# Altium schematic sheet PolarityProtection.SchDoc(PolarityProtection)
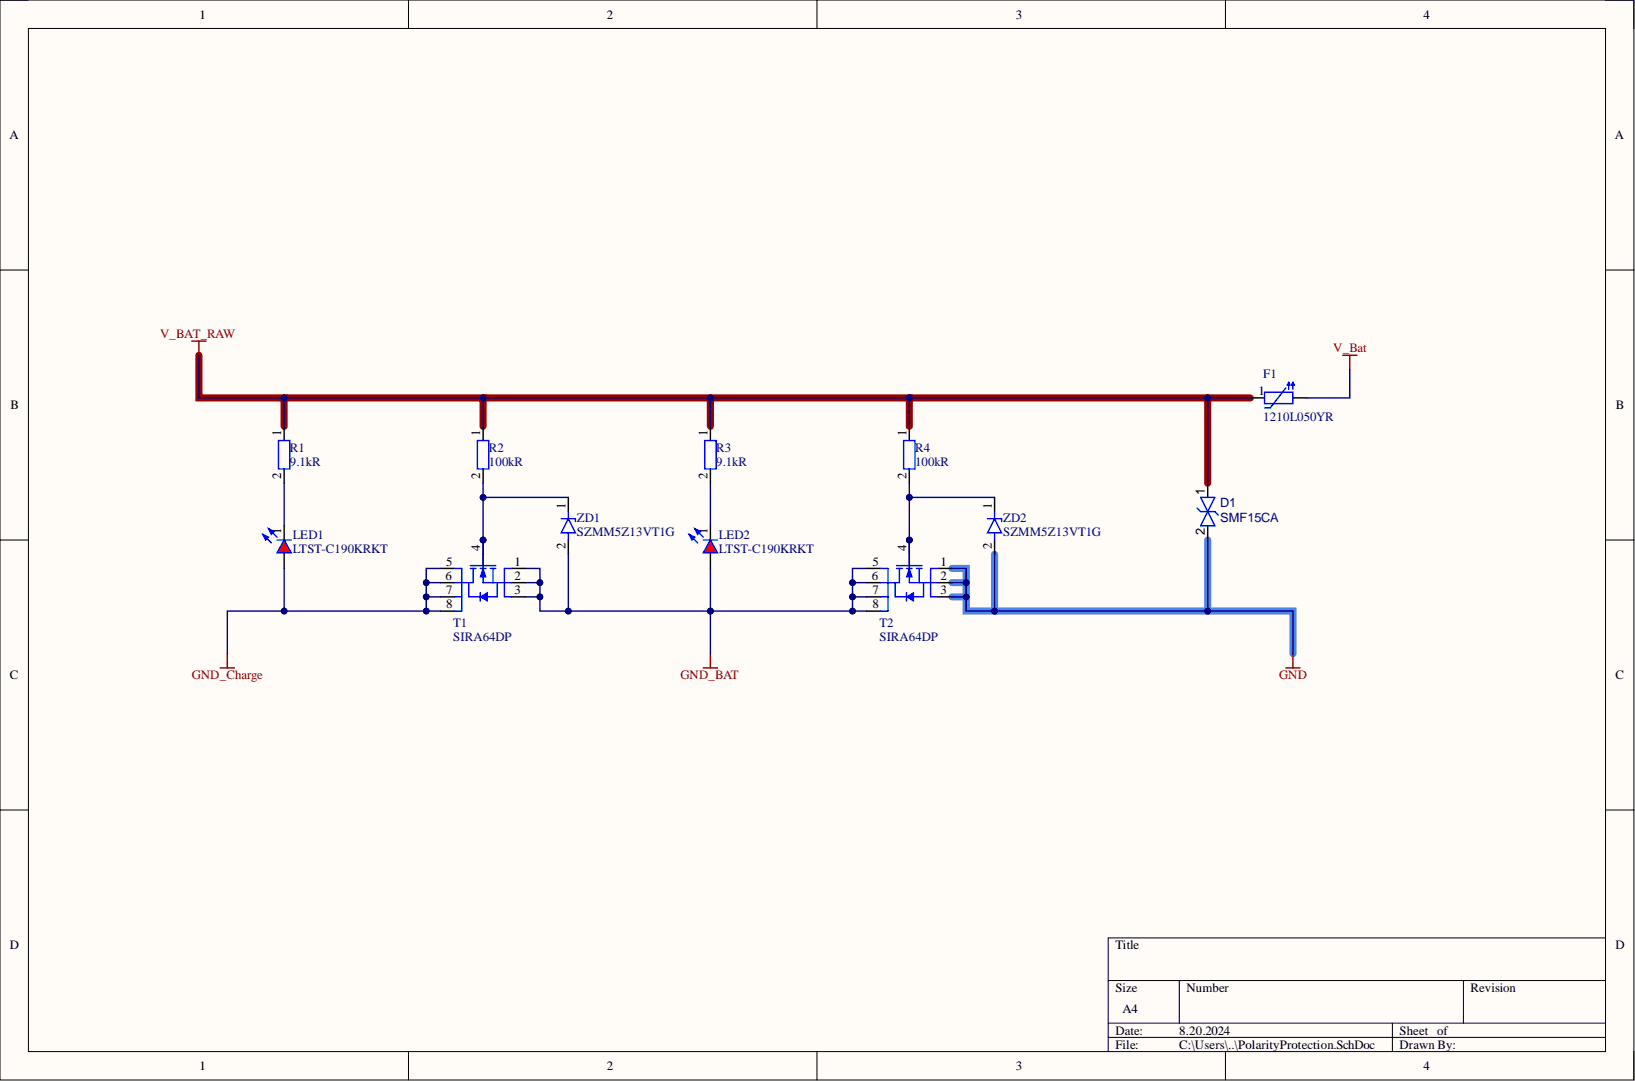
[diagram p0: PolarityProtection.SchDoc]

## Parts
D1  SMF15CA  [SODFL370X110-2L]
F1  1210L050YR  [FUSER3225X08L]
LED1  LTST-C190KRKT  [LEDSC160X80X80-2Lrd]
LED2  LTST-C190KRKT  [LEDSC160X80X80-2Lrd]
R1  9.1kR  [RESC1608X06L]
R2  100kR  [RESC1608X06L]
R3  9.1kR  [RESC1608X06L]
R4  100kR  [RESC1608X06L]
T1  SIRA64DP  [SON127P620X110-9T400X365L]
T2  SIRA64DP  [SON127P620X110-9T400X365L]
ZD1  SZMM5Z13VT1G  [SODFL160X70-2L]
ZD2  SZMM5Z13VT1G  [SODFL160X70-2L]

## Nets
GND: D1.2 T2.1 T2.2 T2.3 ZD2.2
GND_BAT: LED2.2 T1.1 T1.2 T1.3 T2.5 T2.6 T2.7 T2.8 ZD1.2
GND_Charge: LED1.2 T1.5 T1.6 T1.7 T1.8
V_Bat: F1.2
V_BAT_RAW: D1.1 F1.1 R1.1 R2.1 R3.1 R4.1
NetLED1_1: LED1.1 R1.2
NetLED2_1: LED2.1 R3.2
NetR2_2: R2.2 T1.4 ZD1.1
NetR4_2: R4.2 T2.4 ZD2.1

## Library data of the parts
D1 = CMP-004-00062-15: TVS Diode, bidirectional, 15V, 8.2A, 200W, SOD-123FL-2; Connection: single; Case/Package: SOD-123FL-2; Component Kind: Standard; Direction: bidirectional; Mounting Technology: SMD; Peak Current: 8.2A; Peak Power: 200W; Rated Voltage: 15V; Size: 0; Library Name: ZHAW on Altium 365
F1 = CMP-00093-10: Resettable Fuse, Ihold 500mA, Itrip 1A, 13.2V, 1210; Case/Package: 1210; Component Kind: Standard; Ihold: 500mA; Itrip: 1A; Mounting Technology: SMD; Voltage Rating: 13.2V; Library Name: ZHAW on Altium 365
LED1 LED2 = CMP-007-00024-16: LED, Red, 20mA, 2.0V, 0603; Forward Voltage: 2V; Case/Package: 0603; Color: Red; Component Kind: Standard; Mounting Technology: SMD; Rated Current: 20mA; Size: L1.6B0.8H0.8; Library Name: ZHAW on Altium 365
R1 R3 = CMP-009-00472-1: Res, 9.1kR, 1%, 0.1W, 0603; Power: 100mW; Value: 9.1k; Voltage Rating: 75V; Case Code (Metric): 1608; Case/Package: 0603; Component Kind: Standard; Mounting Technology: SMD; Tolerance: 1%; Type: Fixed; Library Name: ZHAW on Altium 365
R2 R4 = CMP-009-00023-30: Res, 100kR, 1%, 0.1W, 0603; Power: 100mW; Value: 100k; Voltage Rating: 50V; Case Code (Metric): 1608; Case/Package: 0603; Component Kind: Standard; Mounting Technology: SMD; Tolerance: 1%; Type: Fixed; Library Name: ZHAW on Altium 365
T1 T2 = CMP-013-00069-15: MOSFET N-Enh, 30V, 60A, 100Ap, 2.1mR, 27.8W, SO-8; Current peak: 100A; Resistance: 2.1m; Case/Package: PowerPAK-SO-8; Component Kind: Standard; Current: 60A; Mounting Technology: SMD; Power: 27.8W; Technology: N-enh; Type: MOSFET; Voltage: 30V; Library Name: ZHAW on Altium 365
ZD1 ZD2 = CMP-004-00080-13: Zener Diode, 13.25V±0.85V, 5mA, 30R, 500mW, SOD-523-2; Current: 100nA; Voltage: 13.25V; Case/Package: SOD-523-2; Component Kind: Standard; Mounting Technology: SMD; Power: 500mW; Type: Zener; Library Name: ZHAW on Altium 365
